# Altium schematic sheet Connectors.SchDoc
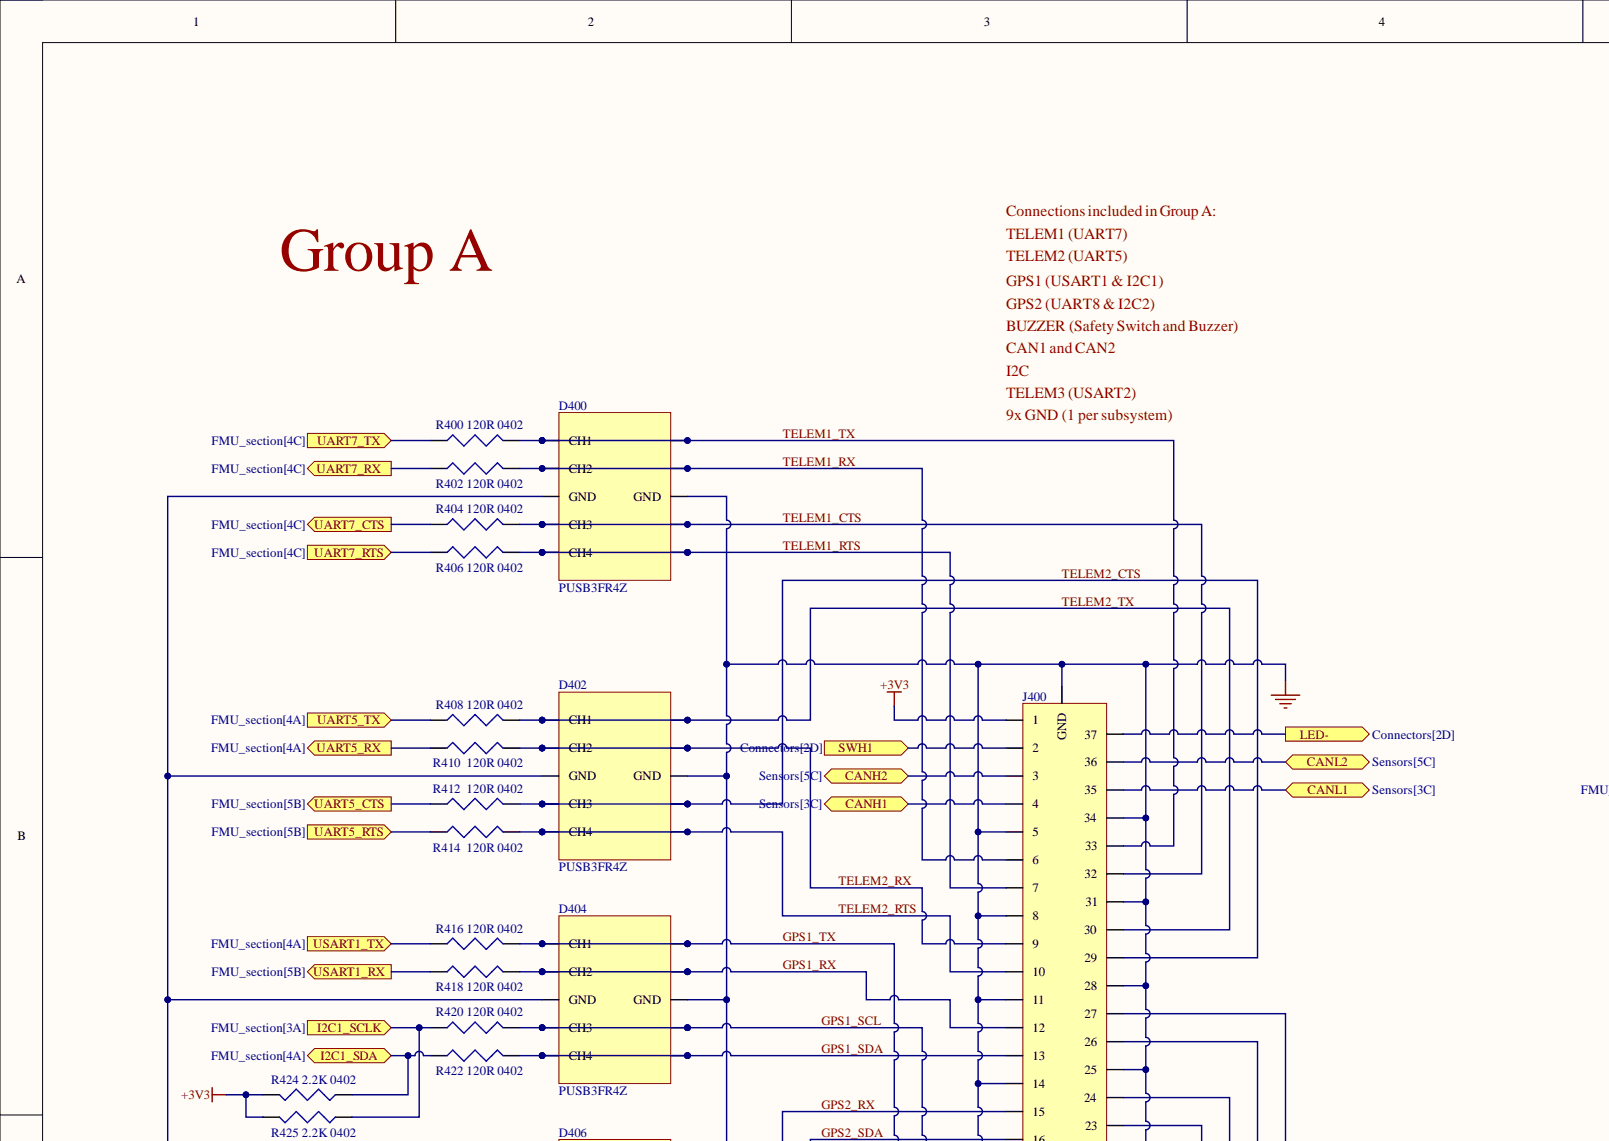
[diagram p0: Connectors.SchDoc, top left]
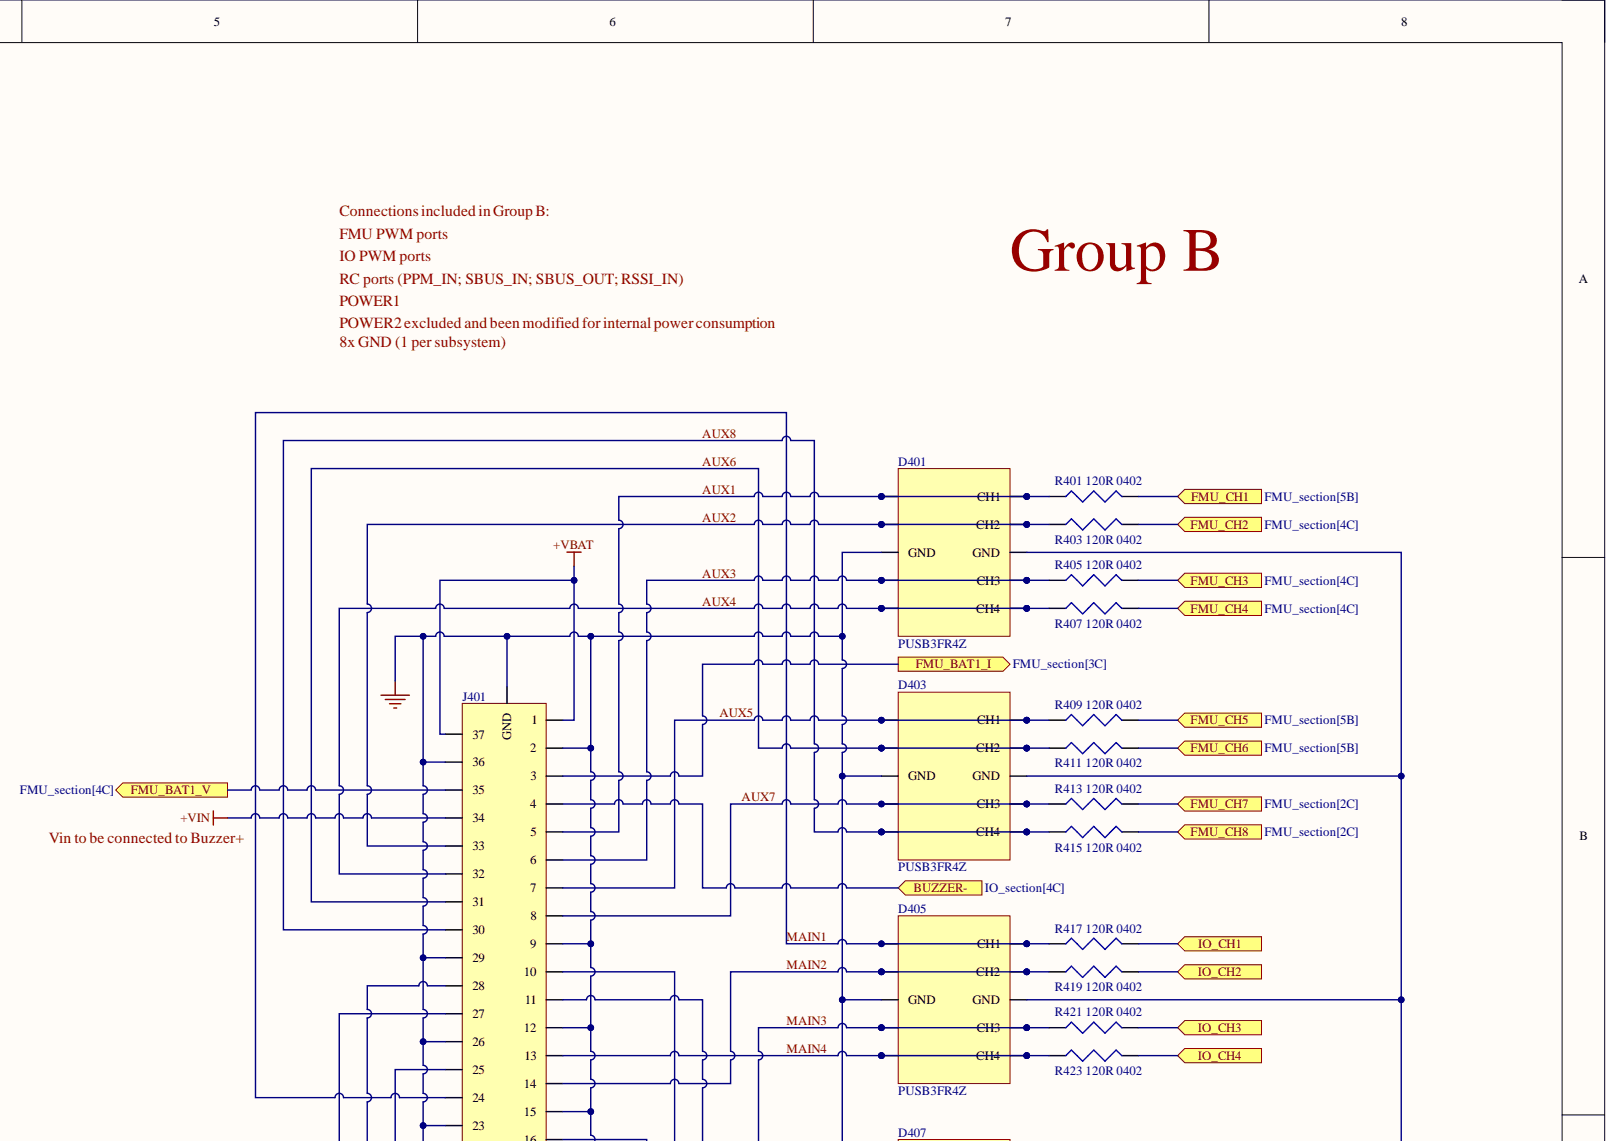
[diagram p1: Connectors.SchDoc, top right]
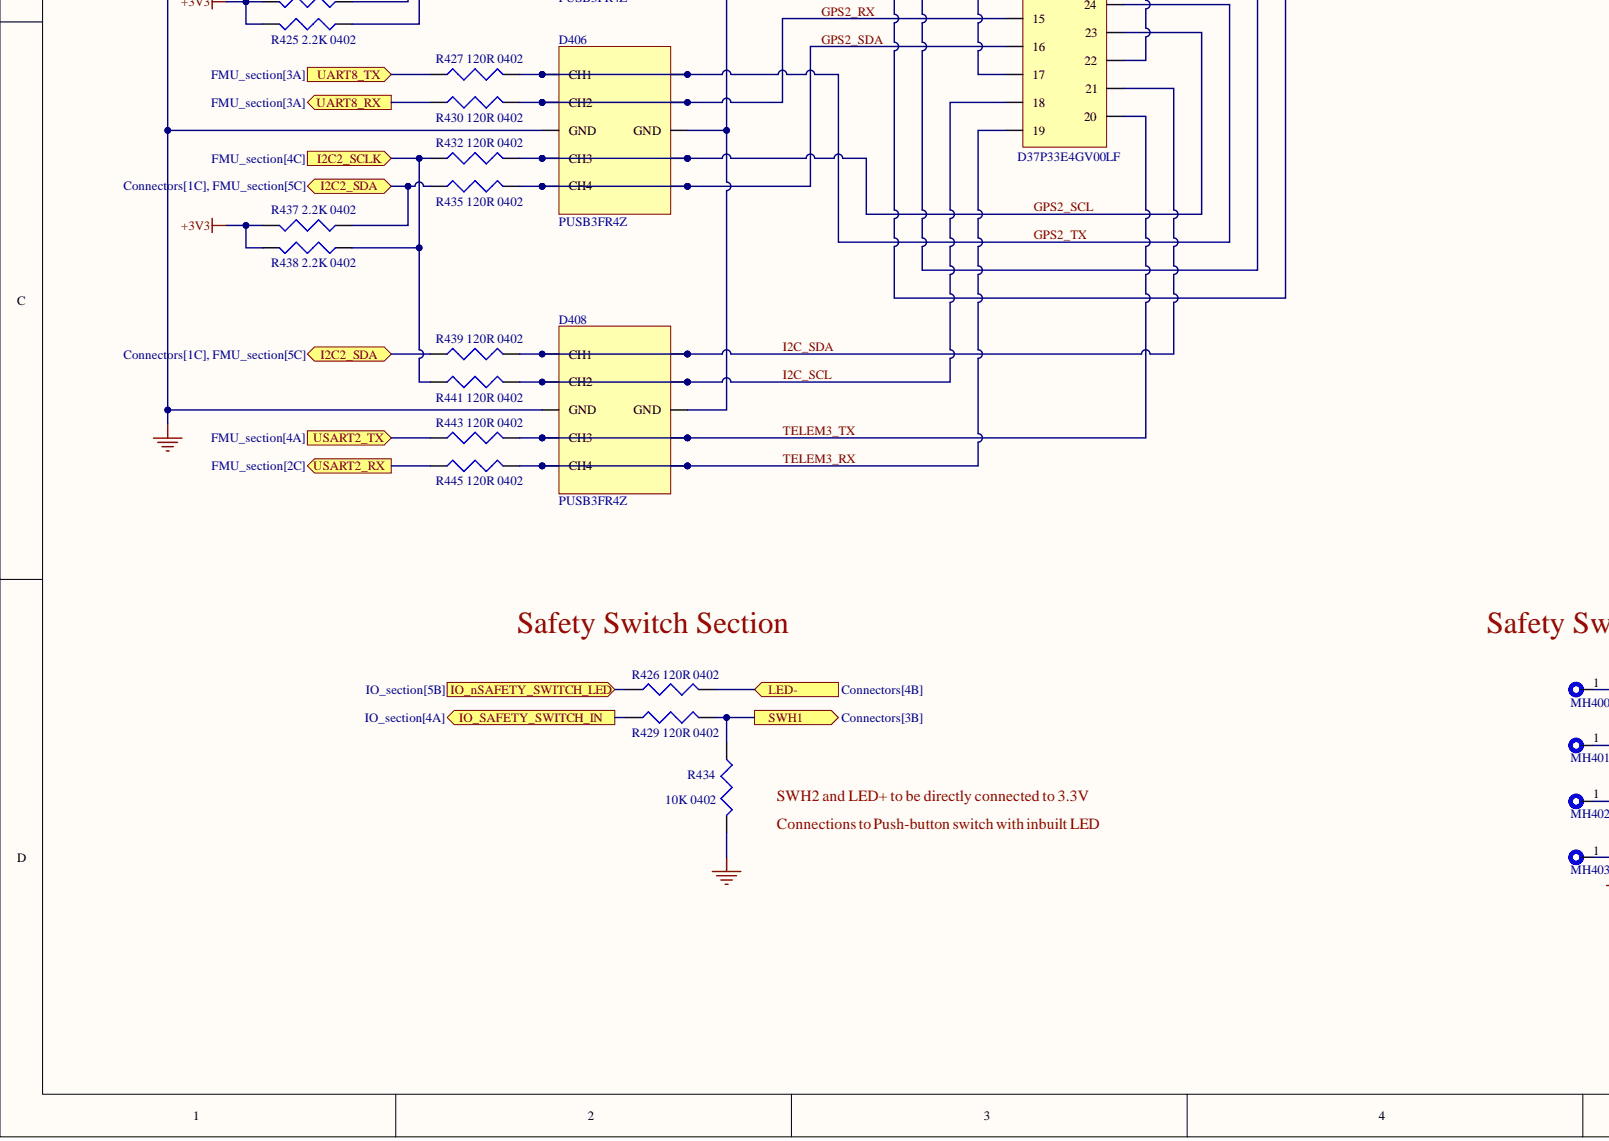
[diagram p2: Connectors.SchDoc, bottom left]
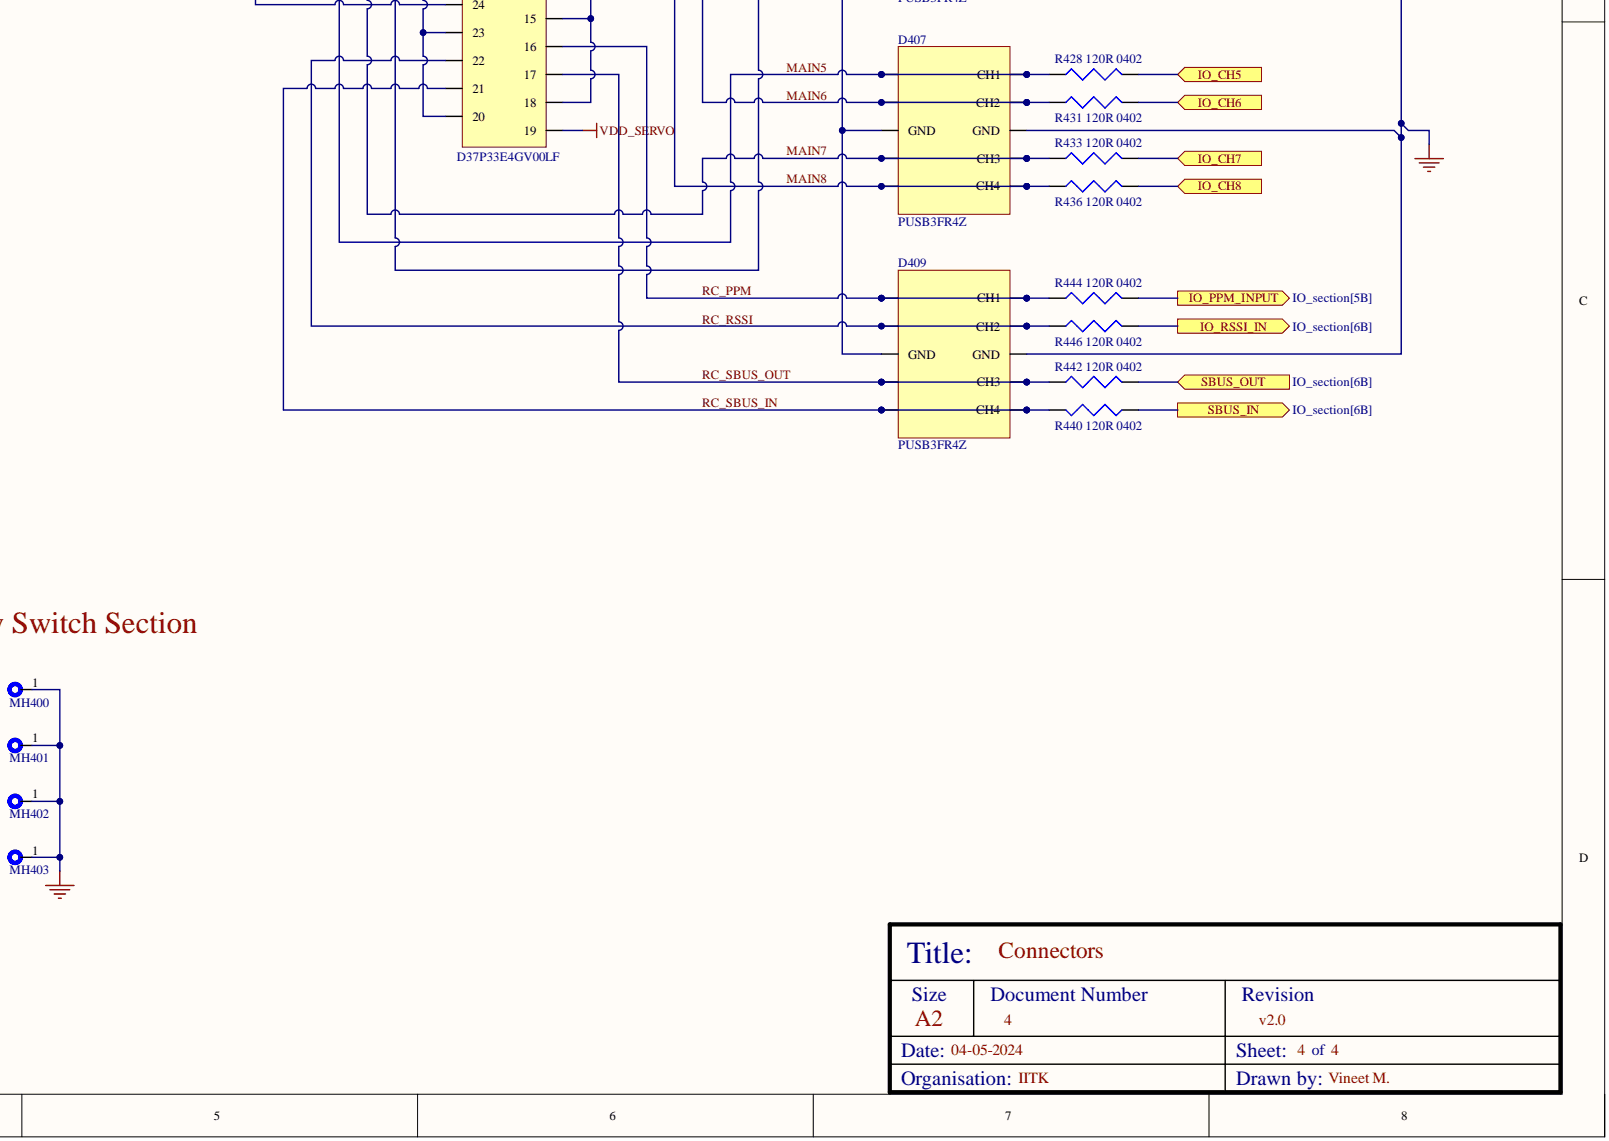
[diagram p3: Connectors.SchDoc, bottom right]

## Parts
D400  PUSB3FR4Z  [PUSB3FR4]
D401  PUSB3FR4Z  [PUSB3FR4]
D402  PUSB3FR4Z  [PUSB3FR4]
D403  PUSB3FR4Z  [PUSB3FR4]
D404  PUSB3FR4Z  [PUSB3FR4]
D405  PUSB3FR4Z  [PUSB3FR4]
D406  PUSB3FR4Z  [PUSB3FR4]
D407  PUSB3FR4Z  [PUSB3FR4]
D408  PUSB3FR4Z  [PUSB3FR4]
D409  PUSB3FR4Z  [PUSB3FR4]
J400  D37P33E4GV00LF  [D37P33E4GV00LF]
J401  D37P33E4GV00LF  [D37P33E4GV00LF]
MH400  M3 mounting holes  [M3_hole]  (value not drawn)  (no BOM)
MH401  M3 mounting holes  [M3_hole]  (value not drawn)  (no BOM)
MH402  M3 mounting holes  [M3_hole]  (value not drawn)  (no BOM)
MH403  M3 mounting holes  [M3_hole]  (value not drawn)  (no BOM)
R400  120R 0402  [R0402]
R401  120R 0402  [R0402]
R402  120R 0402  [R0402]
R403  120R 0402  [R0402]
R404  120R 0402  [R0402]
R405  120R 0402  [R0402]
R406  120R 0402  [R0402]
R407  120R 0402  [R0402]
R408  120R 0402  [R0402]
R409  120R 0402  [R0402]
R410  120R 0402  [R0402]
R411  120R 0402  [R0402]
R412  120R 0402  [R0402]
R413  120R 0402  [R0402]
R414  120R 0402  [R0402]
R415  120R 0402  [R0402]
R416  120R 0402  [R0402]
R417  120R 0402  [R0402]
R418  120R 0402  [R0402]
R419  120R 0402  [R0402]
R420  120R 0402  [R0402]
R421  120R 0402  [R0402]
R422  120R 0402  [R0402]
R423  120R 0402  [R0402]
R424  2.2K 0402  Case Code (Imperial): 0402  [R0402]
R425  2.2K 0402  Case Code (Imperial): 0402  [R0402]
R426  120R 0402  [R0402]
R427  120R 0402  [R0402]
R428  120R 0402  [R0402]
R429  120R 0402  [R0402]
R430  120R 0402  [R0402]
R431  120R 0402  [R0402]
R432  120R 0402  [R0402]
R433  120R 0402  [R0402]
R434  10K 0402  Case/Package: 0402  [R0402]
R435  120R 0402  [R0402]
R436  120R 0402  [R0402]
R437  2.2K 0402  Case Code (Imperial): 0402  [R0402]
R438  2.2K 0402  Case Code (Imperial): 0402  [R0402]
R439  120R 0402  [R0402]
R440  120R 0402  [R0402]
R441  120R 0402  [R0402]
R442  120R 0402  [R0402]
R443  120R 0402  [R0402]
R444  120R 0402  [R0402]
R445  120R 0402  [R0402]
R446  120R 0402  [R0402]

## Ports
BUZZER-, CANH1, CANH2, CANL1, CANL2, FMU_BAT1_I, FMU_BAT1_V, FMU_CH1, FMU_CH2, FMU_CH3, FMU_CH4, FMU_CH5, FMU_CH6, FMU_CH7, FMU_CH8, I2C1_SCLK, I2C1_SDA, I2C2_SCLK, I2C2_SDA, IO_CH1, IO_CH2, IO_CH3, IO_CH4, IO_CH5, IO_CH6, IO_CH7, IO_CH8, IO_nSAFETY_SWITCH_LED, IO_PPM_INPUT, IO_RSSI_IN, IO_SAFETY_SWITCH_IN, LED-, SBUS_IN, SBUS_OUT, SWH1, UART5_CTS, UART5_RTS, UART5_RX, UART5_TX, UART7_CTS, UART7_RTS, UART7_RX, UART7_TX, UART8_RX, UART8_TX, USART1_RX, USART1_TX, USART2_RX, USART2_TX

## Nets
+3V3: J400.1 R424.2 R425.2 R437.2 R438.2
+VBAT: J401.1 J401.37
+VIN: J401.34
GND: D400.3(GND) D400.8(GND) D401.3(GND) D401.8(GND) D402.3(GND) D402.8(GND) D403.3(GND) D403.8(GND) D404.3(GND) D404.8(GND) D405.3(GND) D405.8(GND) D406.3(GND) D406.8(GND) D407.3(GND) D407.8(GND) D408.3(GND) D408.8(GND) D409.3(GND) D409.8(GND) J400.5 J400.8 J400.11 J400.14 J400.17 J400.22 J400.25 J400.28 J400.31 J400.34 J400.38(GND) J401.2 J401.9 J401.12 J401.15 J401.18 J401.20 J401.23 J401.26 J401.29 J401.36 J401.38(GND) MH400.1 MH401.1 MH402.1 MH403.1 R434.2
VDD_SERVO: J401.19
AUX1: D401.1(CH1) D401.10 J401.5 R401.1
AUX2: D401.2(CH2) D401.9 J401.33 R403.1
AUX3: D401.4(CH3) D401.7 J401.6 R405.1
AUX4: D401.5(CH4) D401.6 J401.32 R407.1
AUX5: D403.1(CH1) D403.10 J401.7 R409.1
AUX6: D403.2(CH2) D403.9 J401.31 R411.1
AUX7: D403.4(CH3) D403.7 J401.8 R413.1
AUX8: D403.5(CH4) D403.6 J401.30 R415.1
BUZZER-: J401.4 ; port BUZZER-
CANH1: J400.4 ; port CANH1
CANH2: J400.3 ; port CANH2
CANL1: J400.35 ; port CANL1
CANL2: J400.36 ; port CANL2
FMU_BAT1_I: J401.3 ; port FMU_BAT1_I
FMU_BAT1_V: J401.35 ; port FMU_BAT1_V
FMU_CH1: R401.2 ; port FMU_CH1
FMU_CH2: R403.2 ; port FMU_CH2
FMU_CH3: R405.2 ; port FMU_CH3
FMU_CH4: R407.2 ; port FMU_CH4
FMU_CH5: R409.2 ; port FMU_CH5
FMU_CH6: R411.2 ; port FMU_CH6
FMU_CH7: R413.2 ; port FMU_CH7
FMU_CH8: R415.2 ; port FMU_CH8
GPS1_RX: D404.2(CH2) D404.9 J400.12 R418.2
GPS1_SCL: D404.4(CH3) D404.7 J400.26 R420.2
GPS1_SDA: D404.5(CH4) D404.6 J400.13 R422.2
GPS1_TX: D404.1(CH1) D404.10 J400.27 R416.2
GPS2_RX: D406.2(CH2) D406.9 J400.15 R430.2
GPS2_SCL: D406.4(CH3) D406.7 J400.23 R432.2
GPS2_SDA: D406.5(CH4) D406.6 J400.16 R435.2
GPS2_TX: D406.1(CH1) D406.10 J400.24 R427.2
I2C1_SCLK: R420.1 R425.1 ; port I2C1_SCLK
I2C1_SDA: R422.1 R424.1 ; port I2C1_SDA
I2C2_SCLK: R432.1 R438.1 R441.1 ; port I2C2_SCLK
I2C2_SDA: R435.1 R437.1 R439.1 ; port I2C2_SDA
I2C_SCL: D408.2(CH2) D408.9 J400.18 R441.2
I2C_SDA: D408.1(CH1) D408.10 J400.21 R439.2
IO_CH1: R417.2 ; port IO_CH1
IO_CH2: R419.2 ; port IO_CH2
IO_CH3: R421.2 ; port IO_CH3
IO_CH4: R423.2 ; port IO_CH4
IO_CH5: R428.2 ; port IO_CH5
IO_CH6: R431.2 ; port IO_CH6
IO_CH7: R433.2 ; port IO_CH7
IO_CH8: R436.2 ; port IO_CH8
IO_nSAFETY_SWITCH_LED: R426.1 ; port IO_nSAFETY_SWITCH_LED
IO_PPM_INPUT: R444.2 ; port IO_PPM_INPUT
IO_RSSI_IN: R446.2 ; port IO_RSSI_IN
IO_SAFETY_SWITCH_IN: R429.1 ; port IO_SAFETY_SWITCH_IN
LED-: J400.37 R426.2 ; port LED-
MAIN1: D405.1(CH1) D405.10 J401.24 R417.1
MAIN2: D405.2(CH2) D405.9 J401.14 R419.1
MAIN3: D405.4(CH3) D405.7 J401.25 R421.1
MAIN4: D405.5(CH4) D405.6 J401.13 R423.1
MAIN5: D407.1(CH1) D407.10 J401.27 R428.1
MAIN6: D407.2(CH2) D407.9 J401.11 R431.1
MAIN7: D407.4(CH3) D407.7 J401.28 R433.1
MAIN8: D407.5(CH4) D407.6 J401.10 R436.1
RC_PPM: D409.1(CH1) D409.10 J401.16 R444.1
RC_RSSI: D409.2(CH2) D409.9 J401.22 R446.1
RC_SBUS_IN: D409.5(CH4) D409.6 J401.21 R440.2
RC_SBUS_OUT: D409.4(CH3) D409.7 J401.17 R442.1
SBUS_IN: R440.1 ; port SBUS_IN
SBUS_OUT: R442.2 ; port SBUS_OUT
SWH1: J400.2 R429.2 R434.1 ; port SWH1
TELEM1_CTS: D400.4(CH3) D400.7 J400.32 R404.2
TELEM1_RTS: D400.5(CH4) D400.6 J400.7 R406.2
TELEM1_RX: D400.2(CH2) D400.9 J400.6 R402.2
TELEM1_TX: D400.1(CH1) D400.10 J400.33 R400.2
TELEM2_CTS: D402.4(CH3) D402.7 J400.29 R412.2
TELEM2_RTS: D402.5(CH4) D402.6 J400.10 R414.2
TELEM2_RX: D402.2(CH2) D402.9 J400.9 R410.2
TELEM2_TX: D402.1(CH1) D402.10 J400.30 R408.2
TELEM3_RX: D408.5(CH4) D408.6 J400.19 R445.2
TELEM3_TX: D408.4(CH3) D408.7 J400.20 R443.2
UART5_CTS: R412.1 ; port UART5_CTS
UART5_RTS: R414.1 ; port UART5_RTS
UART5_RX: R410.1 ; port UART5_RX
UART5_TX: R408.1 ; port UART5_TX
UART7_CTS: R404.1 ; port UART7_CTS
UART7_RTS: R406.1 ; port UART7_RTS
UART7_RX: R402.1 ; port UART7_RX
UART7_TX: R400.1 ; port UART7_TX
UART8_RX: R430.1 ; port UART8_RX
UART8_TX: R427.1 ; port UART8_TX
USART1_RX: R418.1 ; port USART1_RX
USART1_TX: R416.1 ; port USART1_TX
USART2_RX: R445.1 ; port USART2_RX
USART2_TX: R443.1 ; port USART2_TX

## Library data of the parts
D400-D409 = PUSB3FR4Z: 3V (Typ) Clamp 5A (8/20µs) Ipp Tvs Diode Surface Mount DFN2510A-10; Manufacturer: Nexperia; Diode Element Material: SILICON; Diode Type: TRANS VOLTAGE SUPPRESSOR; Min Breakdown Voltage: 5.5V; Number of Elements: 4; Package Body Material: Plastic; Polarity: Unidirectional; Reference Standard: IEC-60134; Category: ESD and Circuit Protection ICs; Component Kind: Standard; ComponentLink1Description: https://datasheet.ciiva.com:443/pdfs/VipMasterIC/IC/NEXP/NEXP-S-A0002907422/NEXP-S-A0002907422-1.pdf?src-supplier=IHS+Markit; ComponentLink1URL: https://datasheet.ciiva.com:443/pdfs/VipMasterIC/IC/NEXP/NEXP-S-A0002907422/NEXP-S-A0002907422-1.pdf?src-supplier=IHS+Markit; ComponentLink2Description: http://www.farnell.com/datasheets/1961315.pdf?src-supplier=Farnell; ComponentLink2URL: http://www.farnell.com/datasheets/1961315.pdf?src-supplier=Farnell; ComponentLink3Description: https://assets.nexperia.com/documents/data-sheet/PUSB3FR4.pdf?src-supplier=Digi-Key; ComponentLink3URL: https://assets.nexperia.com/documents/data-sheet/PUSB3FR4.pdf?src-supplier=Digi-Key; ComponentLink4Description: http://www.farnell.com/datasheets/1961315.pdf?src-supplier=Element14; ComponentLink4URL: http://www.farnell.com/datasheets/1961315.pdf?src-supplier=Element14; ComponentLink5Description: https://datasheet.octopart.com/PUSB3FR4Z-Nexperia-datasheet-37004474.pdf?src-supplier=Future+Electronics; ComponentLink5URL: https://datasheet.octopart.com/PUSB3FR4Z-Nexperia-datasheet-37004474.pdf?src-supplier=Future+Electronics; ComponentLink6Description: http://datasheet.octopart.com/PUSB3FR4Z-Nexperia-datasheet-87845463.pdf?src-supplier=Digi-Key; ComponentLink6URL: http://datasheet.octopart.com/PUSB3FR4Z-Nexperia-datasheet-87845463.pdf?src-supplier=Digi-Key; ComponentLink7Description: http://datasheet.octopart.com/PUSB3FR4Z-Nexperia-datasheet-87845463.pdf?src-supplier=Rs; ComponentLink7URL: http://datasheet.octopart.com/PUSB3FR4Z-Nexperia-datasheet-87845463.pdf?src-supplier=Rs; ComponentLink8Description: http://datasheet.octopart.com/PUSB3FR4Z-Nexperia-datasheet-87845463.pdf?src-supplier=Avnet; ComponentLink8URL: http://datasheet.octopart.com/PUSB3FR4Z-Nexperia-datasheet-87845463.pdf?src-supplier=Avnet; ComponentLink9Description: http://datasheet.octopart.com/PUSB3FR4Z-Nexperia-datasheet-87845463.pdf?src-supplier=Verical; ComponentLink9URL: http://datasheet.octopart.com/PUSB3FR4Z-Nexperia-datasheet-87845463.pdf?src-supplier=Verical; ComponentLink10Description: http://datasheet.octopart.com/PUSB3FR4Z-Nexperia-datasheet-87845463.pdf?src-supplier=Future+Electronics; ComponentLink10URL: http://datasheet.octopart.com/PUSB3FR4Z-Nexperia-datasheet-87845463.pdf?src-supplier=Future+Electronics; ComponentLink11Description: http://www.farnell.com/datasheets/1961315.pdf?src-supplier=Newark; ComponentLink11URL: http://www.farnell.com/datasheets/1961315.pdf?src-supplier=Newark; ComponentLink12Description: http://datasheet.octopart.com/PUSB3FR4Z-Nexperia-datasheet-87845463.pdf?src-supplier=Mouser; ComponentLink12URL: http://datasheet.octopart.com/PUSB3FR4Z-Nexperia-datasheet-87845463.pdf?src-supplier=Mouser; ComponentLink13Description: https://datasheet.octopart.com/PUSB3FR4Z-Nexperia-datasheet-155953997.pdf?src-supplier=TME; ComponentLink13URL: https://datasheet.octopart.com/PUSB3FR4Z-Nexperia-datasheet-155953997.pdf?src-supplier=TME; ComponentLink14Description: http://datasheet.octopart.com/PUSB3FR4Z-Nexperia-datasheet-87845463.pdf?src-supplier=TTI; ComponentLink14URL: http://datasheet.octopart.com/PUSB3FR4Z-Nexperia-datasheet-87845463.pdf?src-supplier=TTI; Library Name: Components.SchLib; Manufacturer Part Number: PUSB3FR4Z; Max Operating Temperature: 85°C; Min Operating Temperature: -40°C; Package Shape: Rectangular; Package Style: SMALL OUTLINEMeter; Pins: 10; Pricing: 1=0.43, 10=0.349, 25=0.3192, 100=0.2376, 250=0.21528, 500=0.17816, 1000=0.13362, 2500=0.12249 (USD); Reach SVHC Compliant: Yes; RoHS: Yes; RoHS Compliant: Yes; Stock: 26815; Supplier: Digi-Key; Supplier Part Number: 1727-2026-1-ND; Surface Mount: Yes; Technology: AVALANCHE; Terminal Form: No Lead; Terminal Position: DUAL
J400 J401 = D37P33E4GV00LF: 37 Position D-Sub Plug, Male Pins Connector; Manufacturer: Amphenol ICC / FCI; Category: D-Sub Connectors; Component Kind: Standard; ComponentLink1Description: https://datasheet.ciiva.com/14856/c01-8646-0825-14856389.pdf?src-supplier=Arrow+Electronics; ComponentLink1URL: https://datasheet.ciiva.com/14856/c01-8646-0825-14856389.pdf?src-supplier=Arrow+Electronics; ComponentLink2Description: https://datasheet.ciiva.com/658/c01-8646-0151-658592.pdf?src-supplier=Digi-Key; ComponentLink2URL: https://datasheet.ciiva.com/658/c01-8646-0151-658592.pdf?src-supplier=Digi-Key; Library Name: Components.SchLib; Manufacturer Part Number: D37P33E4GV00LF; Pricing: 1=2.88, 15=2.618, 30=2.47933, 45=2.42178, 90=2.30644, 240=2.01813, 450=1.96047, 945=1.67217, 2400=1.55685 (USD); RoHS: Yes; Stock: 181; Supplier: Digi-Key; Supplier Part Number: 609-6502-ND
MH400-MH403 = M3_hole: M3 mounting hole; Library Name: Components.IntLib
R400-R423 R426-R433 R435 R436 R439-R446 = CRCW0402120RFKED: 120 Ohms ±1% 0.063W, 1/16W Chip Resistor 0402 (1005 Metric) Automotive AEC-Q200 Thick Film; Manufacturer: Vishay; Category: Chip SMD Resistors; Component Kind: Standard; ComponentLink1Description: https://datasheet.ciiva.com/11817/0900766b80d98824-11817989.pdf?src-supplier=Rs; ComponentLink1URL: https://datasheet.ciiva.com/11817/0900766b80d98824-11817989.pdf?src-supplier=Rs; ComponentLink2Description: https://datasheet.ciiva.com/2463/dcrcwe3-2463110.pdf?src-supplier=Digi-Key; ComponentLink2URL: https://datasheet.ciiva.com/2463/dcrcwe3-2463110.pdf?src-supplier=Digi-Key; ComponentLink3Description: https://datasheet.ciiva.com/2463/dcrcwe3-2463109.pdf?src-supplier=Digi-Key; ComponentLink3URL: https://datasheet.ciiva.com/2463/dcrcwe3-2463109.pdf?src-supplier=Digi-Key; ComponentLink4Description: https://datasheet.ciiva.com/2463/dcrcwe3-2463111.pdf?src-supplier=Digi-Key; ComponentLink4URL: https://datasheet.ciiva.com/2463/dcrcwe3-2463111.pdf?src-supplier=Digi-Key; ComponentLink5Description: https://datasheet.ciiva.com/3256/dcrcwe3-3256009.pdf?src-supplier=Avnet; ComponentLink5URL: https://datasheet.ciiva.com/3256/dcrcwe3-3256009.pdf?src-supplier=Avnet; ComponentLink6Description: https://datasheet.ciiva.com/8336/dcrcwe3-8336661.pdf?src-supplier=Avnet; ComponentLink6URL: https://datasheet.ciiva.com/8336/dcrcwe3-8336661.pdf?src-supplier=Avnet; ComponentLink7Description: https://datasheet.ciiva.com/22674/1912744-22674687.pdf?src-supplier=Element14; ComponentLink7URL: https://datasheet.ciiva.com/22674/1912744-22674687.pdf?src-supplier=Element14; ComponentLink8Description: https://datasheet.ciiva.com/7777/1697337-7777194.pdf?src-supplier=Element14; ComponentLink8URL: https://datasheet.ciiva.com/7777/1697337-7777194.pdf?src-supplier=Element14; ComponentLink9Description: https://datasheet.ciiva.com/7777/1697337-7777195.pdf?src-supplier=Element14; ComponentLink9URL: https://datasheet.ciiva.com/7777/1697337-7777195.pdf?src-supplier=Element14; ComponentLink10Description: https://datasheet.ciiva.com/5550/dcrcwe3-109170-5550502.pdf?src-supplier=Mouser; ComponentLink10URL: https://datasheet.ciiva.com/5550/dcrcwe3-109170-5550502.pdf?src-supplier=Mouser; Library Name: Components.IntLib; Manufacturer Part Number: CRCW0402120RFKED; Pricing: 1=0.1, 10=0.033, 50=0.0182, 100=0.0134, 500=0.00818, 1000=0.00602, 5000=0.00432 (USD); RoHS: Yes; Stock: 24743; Supplier: Digi-Key; Supplier Part Number: 541-120LCT-ND
R424 R425 R437 R438 = CRCW04022K20FKED: 2.2 kOhms ±1% 0.063W, 1/16W Chip Resistor 0402 (1005 Metric) Automotive AEC-Q200 Thick Film; Manufacturer: Vishay; Max Power Dissipation: 63mW; Temperature Coefficient: 100ppm/°C; Value: 2.2kR; Voltage Rating: 50V; Case Code (Metric): 1005; Case/Package: 0402; Category: Chip SMD Resistors; Component Kind: Standard; ComponentLink1Description: https://datasheet.ciiva.com/11811/0900766b80d98824-11811709.pdf?src-supplier=Rs; ComponentLink1URL: https://datasheet.ciiva.com/11811/0900766b80d98824-11811709.pdf?src-supplier=Rs; ComponentLink2Description: https://datasheet.ciiva.com/26316/fld-crcw-e3-data-en-26316650.pdf?src-supplier=Distrelec; ComponentLink2URL: https://datasheet.ciiva.com/26316/fld-crcw-e3-data-en-26316650.pdf?src-supplier=Distrelec; ComponentLink3Description: https://datasheet.ciiva.com/7777/1697337-7777228.pdf?src-supplier=Element14; ComponentLink3URL: https://datasheet.ciiva.com/7777/1697337-7777228.pdf?src-supplier=Element14; ComponentLink4Description: https://datasheet.ciiva.com/7777/1697337-7777227.pdf?src-supplier=Element14; ComponentLink4URL: https://datasheet.ciiva.com/7777/1697337-7777227.pdf?src-supplier=Element14; ComponentLink5Description: https://datasheet.ciiva.com/2464/dcrcwe3-2464486.pdf?src-supplier=Digi-Key; ComponentLink5URL: https://datasheet.ciiva.com/2464/dcrcwe3-2464486.pdf?src-supplier=Digi-Key; ComponentLink6Description: https://datasheet.ciiva.com/5541/dcrcwe3-109170-5541416.pdf?src-supplier=Mouser; ComponentLink6URL: https://datasheet.ciiva.com/5541/dcrcwe3-109170-5541416.pdf?src-supplier=Mouser; ComponentLink7Description: https://datasheet.ciiva.com/3256/dcrcwe3-3256341.pdf?src-supplier=Avnet; ComponentLink7URL: https://datasheet.ciiva.com/3256/dcrcwe3-3256341.pdf?src-supplier=Avnet; ComponentLink8Description: https://datasheet.ciiva.com/16209/dcrcwe3-16209157.pdf?src-supplier=Future+Electronics; ComponentLink8URL: https://datasheet.ciiva.com/16209/dcrcwe3-16209157.pdf?src-supplier=Future+Electronics; ComponentLink9Description: https://datasheet.ciiva.com/2464/dcrcwe3-2464487.pdf?src-supplier=Digi-Key; ComponentLink9URL: https://datasheet.ciiva.com/2464/dcrcwe3-2464487.pdf?src-supplier=Digi-Key; ComponentLink10Description: https://datasheet.ciiva.com/2464/dcrcwe3-2464488.pdf?src-supplier=Digi-Key; ComponentLink10URL: https://datasheet.ciiva.com/2464/dcrcwe3-2464488.pdf?src-supplier=Digi-Key; ComponentLink11Description: https://datasheet.ciiva.com/16778/70201119-16778943.pdf?src-supplier=Allied+Electronics; ComponentLink11URL: https://datasheet.ciiva.com/16778/70201119-16778943.pdf?src-supplier=Allied+Electronics; Construction: Rectangular; Contact Plating: Tin; Depth: 0.5mm; Features: Automotive AEC-Q200; Height: 0.35mm; Lead Free: Lead Free; Length: 1mm; Library Name: Components.IntLib; Manufacturer Part Number: CRCW04022K20FKED; Max Operating Temperature: 155°C; Military Standard: Not; Min Operating Temperature: -55°C; Number of Terminations: 2; Package Quantity: 10; Packaging: Tape and Reel; Power Rating: 63mW; Pricing: 1=0.1, 10=0.033, 50=0.0182, 100=0.0134, 500=0.00818, 1000=0.00602, 5000=0.00432 (USD); Radiation Hardening: No; Ratings: AEC-Q200; Reach SVHC Compliant: Unknown; Resistance Tolerance: 1%; Resistor Type: Thick Film; RoHS: Yes; RoHS Compliant: Yes; Series: CRCW; Stock: 1272851; Supplier: Digi-Key; Supplier Part Number: 541-2.20KLCT-ND; Technology: THICK FILM; Termination: SMD/SMT; Tolerance: 1%; Voltage Rating (DC): 50V; Weight: 2.3E-05oz; Width: 0.02inch
R434 = CRCW040210K0FKED: Thick Film Resistors - SMD 1/16watt 10Kohms 1%; Manufacturer: Vishay; Package Height: 0.35mm; Package Length: 1mm; Package Width: 0.5mm; Power: 63mW; Rated Temperature: 70°C; Reference Standard: AEC-Q200; Temperature Coefficient: 100ppm/°C; Value: 10kR; Working Voltage: 50V; Category: Chip SMD Resistors; Component Kind: Standard; ComponentLink1Description: https://datasheet.ciiva.com:443/pdfs/VipMasterIC/IC/VISH/VISH-S-A0007266705/VISH-S-A0007266705-1.pdf?src-supplier=IHS+Markit; ComponentLink1URL: https://datasheet.ciiva.com:443/pdfs/VipMasterIC/IC/VISH/VISH-S-A0007266705/VISH-S-A0007266705-1.pdf?src-supplier=IHS+Markit; ComponentLink2Description: http://www.farnell.com/datasheets/2714353.pdf?src-supplier=Farnell; ComponentLink2URL: http://www.farnell.com/datasheets/2714353.pdf?src-supplier=Farnell; ComponentLink3Description: http://www.farnell.com/datasheets/2793682.pdf?src-supplier=Farnell; ComponentLink3URL: http://www.farnell.com/datasheets/2793682.pdf?src-supplier=Farnell; ComponentLink4Description: http://www.farnell.com/datasheets/2714353.pdf?src-supplier=Newark; ComponentLink4URL: http://www.farnell.com/datasheets/2714353.pdf?src-supplier=Newark; ComponentLink5Description: https://datasheet.octopart.com/CRCW040210K0FKED-Vishay-datasheet-5300368.pdf?src-supplier=Future+Electronics; ComponentLink5URL: https://datasheet.octopart.com/CRCW040210K0FKED-Vishay-datasheet-5300368.pdf?src-supplier=Future+Electronics; ComponentLink6Description: http://datasheet.octopart.com/CRCW040210K0FKED-Vishay-datasheet-62317933.pdf?src-supplier=Digi-Key; ComponentLink6URL: http://datasheet.octopart.com/CRCW040210K0FKED-Vishay-datasheet-62317933.pdf?src-supplier=Digi-Key; ComponentLink7Description: https://datasheet.octopart.com/CRCW040210K0FKED-Vishay-datasheet-83961561.pdf?src-supplier=Element14; ComponentLink7URL: https://datasheet.octopart.com/CRCW040210K0FKED-Vishay-datasheet-83961561.pdf?src-supplier=Element14; ComponentLink8Description: http://www.farnell.com/datasheets/2714353.pdf?src-supplier=Element14; ComponentLink8URL: http://www.farnell.com/datasheets/2714353.pdf?src-supplier=Element14; ComponentLink9Description: http://datasheet.octopart.com/CRCW040210K0FKED-Vishay-datasheet-62317933.pdf?src-supplier=Rs; ComponentLink9URL: http://datasheet.octopart.com/CRCW040210K0FKED-Vishay-datasheet-62317933.pdf?src-supplier=Rs; ComponentLink10Description: http://datasheet.octopart.com/CRCW040210K0FKED-Vishay-datasheet-62317933.pdf?src-supplier=Avnet; ComponentLink10URL: http://datasheet.octopart.com/CRCW040210K0FKED-Vishay-datasheet-62317933.pdf?src-supplier=Avnet; ComponentLink11Description: http://www.farnell.com/datasheets/2793682.pdf?src-supplier=Newark; ComponentLink11URL: http://www.farnell.com/datasheets/2793682.pdf?src-supplier=Newark; ComponentLink12Description: https://datasheet.octopart.com/CRCW040210K0FKED.-Vishay-datasheet-78776209.pdf?src-supplier=Newark; ComponentLink12URL: https://datasheet.octopart.com/CRCW040210K0FKED.-Vishay-datasheet-78776209.pdf?src-supplier=Newark; ComponentLink13Description: http://datasheet.octopart.com/CRCW040210K0FKED-Vishay-datasheet-62317933.pdf?src-supplier=Distrelec; ComponentLink13URL: http://datasheet.octopart.com/CRCW040210K0FKED-Vishay-datasheet-62317933.pdf?src-supplier=Distrelec; ComponentLink14Description: http://datasheet.octopart.com/CRCW040210K0FKED-Vishay-datasheet-62317933.pdf?src-supplier=Mouser; ComponentLink14URL: http://datasheet.octopart.com/CRCW040210K0FKED-Vishay-datasheet-62317933.pdf?src-supplier=Mouser; ComponentLink15Description: http://datasheet.octopart.com/CRCW040210K0FKED-Vishay-datasheet-62317933.pdf?src-supplier=Quest; ComponentLink15URL: http://datasheet.octopart.com/CRCW040210K0FKED-Vishay-datasheet-62317933.pdf?src-supplier=Quest; ComponentLink16Description: http://datasheet.octopart.com/CRCW040210K0FKED-Vishay-datasheet-62317933.pdf?src-supplier=TTI; ComponentLink16URL: http://datasheet.octopart.com/CRCW040210K0FKED-Vishay-datasheet-62317933.pdf?src-supplier=TTI; ComponentLink17Description: http://datasheet.octopart.com/CRCW040210K0FKED-Vishay-datasheet-62317933.pdf?src-supplier=Freelance+Electronics; ComponentLink17URL: http://datasheet.octopart.com/CRCW040210K0FKED-Vishay-datasheet-62317933.pdf?src-supplier=Freelance+Electronics; ComponentLink18Description: https://datasheet.octopart.com/CRCW040210K0FKED-Vishay-datasheet-134526670.pdf?src-supplier=TME; ComponentLink18URL: https://datasheet.octopart.com/CRCW040210K0FKED-Vishay-datasheet-134526670.pdf?src-supplier=TME; Construction: Chip; JESD-609 Code: e3; Library Name: Components.IntLib; Manufacturer Part Number: CRCW040210K0FKED; Max Operating Temperature: 155°C; Min Operating Temperature: -55°C; Mounting Technology: Surface Mount; Package Shape: Rectangular; Package Style: SMTMeter; Packaging: Tape and Reel; Pins: 2; Pricing: 1=0.1, 10=0.019, 50=0.019, 100=0.009, 1000=0.006, 10000=0.003 (USD); Reach SVHC Compliant: Yes; Resistor Type: FIXED RESISTOR; RoHS: Yes; RoHS Compliant: Yes; Stock: 1050191; Supplier: Mouser; Supplier Part Number: 71-CRCW0402-10K-E3; Technology: METAL GLAZE/THICK FILM; Terminal Finish: Nickel; Termination: Wraparound; Tolerance: 1%
